# Altium schematic sheet Eco-Stationnement_Board_Connecteur.SchDoc(Eco-Stationnement_Board_Connecteur)
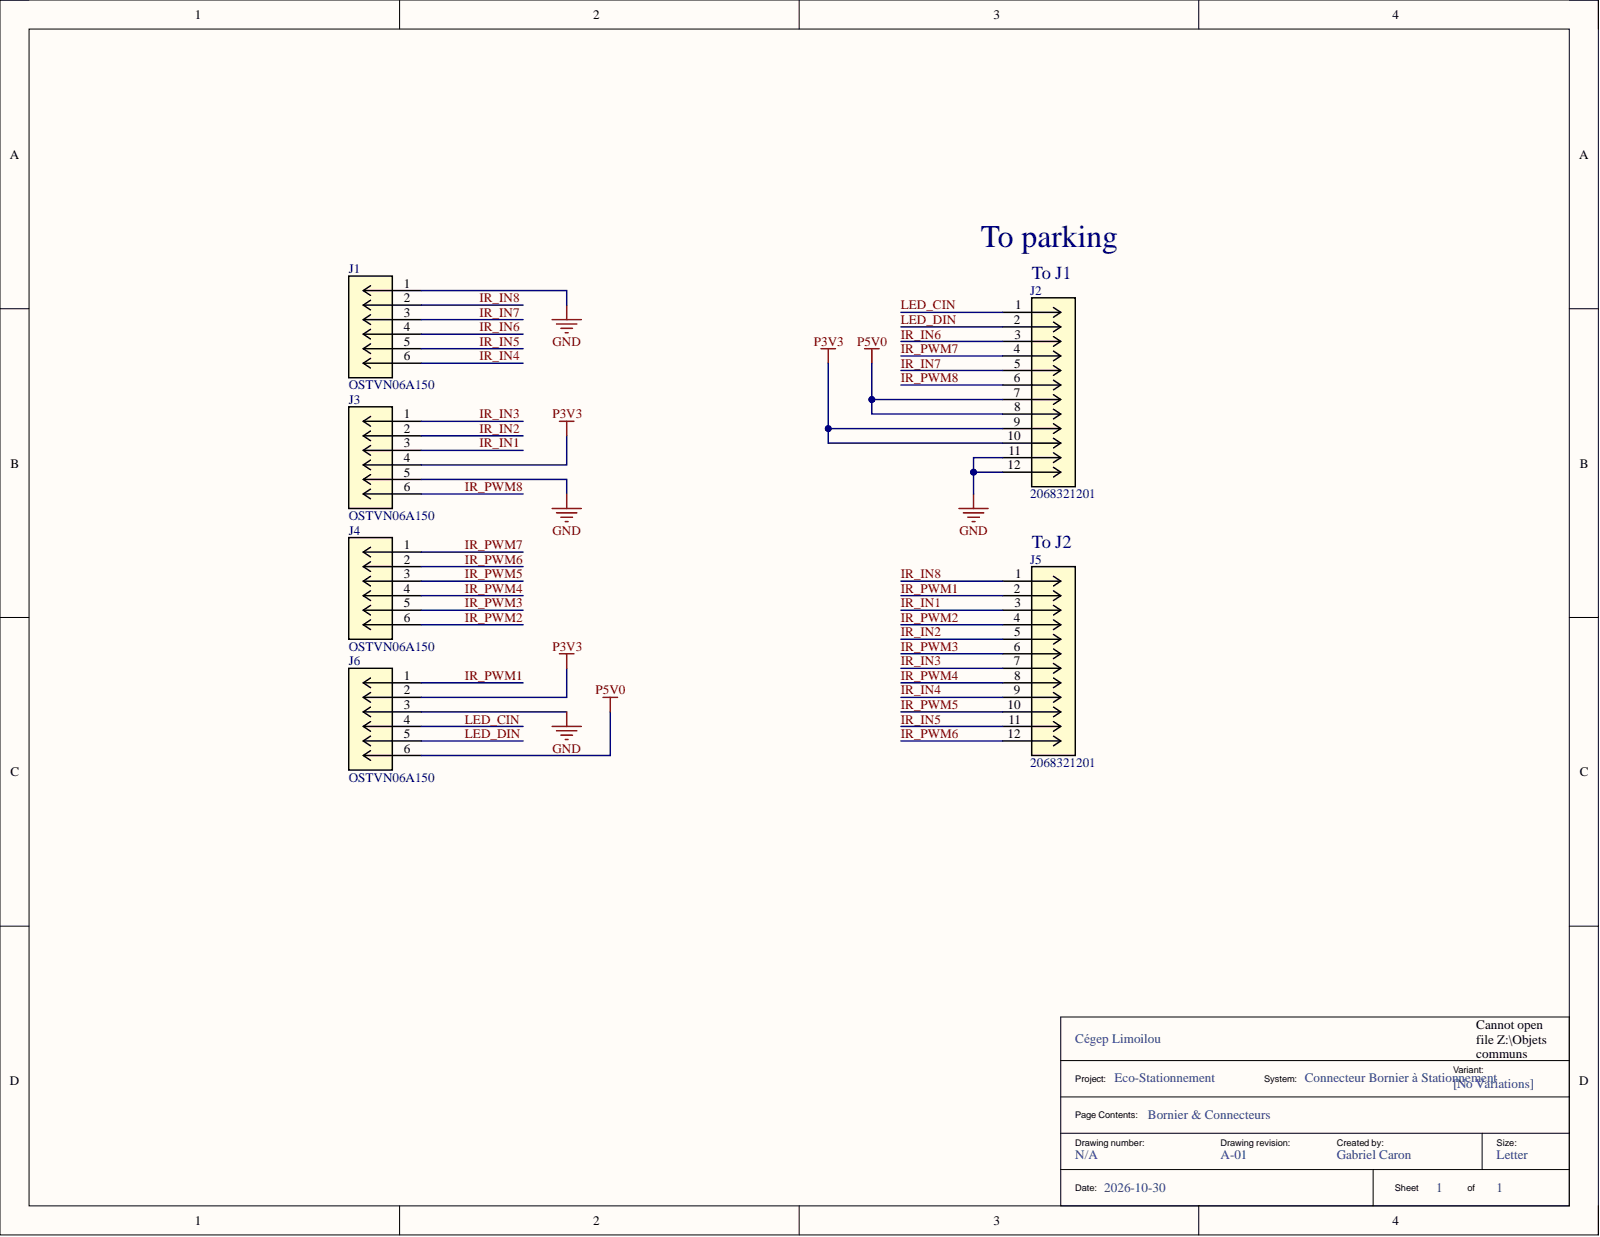
[diagram p0: Eco-Stationnement_Board_Connecteur.SchDoc]

## Parts
J1  OSTVN06A150  [CONN_OSTVN06A150_OST]
J2  2068321201  [CONN_2068320000-SD_12_MOL]
J3  OSTVN06A150  [CONN_OSTVN06A150_OST]
J4  OSTVN06A150  [CONN_OSTVN06A150_OST]
J5  2068321201  [CONN_2068320000-SD_12_MOL]
J6  OSTVN06A150  [CONN_OSTVN06A150_OST]

## Nets
GND: J1.1 J2.11 J2.12 J3.5 J6.3
P3V3: J2.9 J2.10 J3.4 J6.2
P5V0: J2.7 J2.8 J6.6
IR_IN1: J3.3 J5.3
IR_IN2: J3.2 J5.5
IR_IN3: J3.1 J5.7
IR_IN4: J1.6 J5.9
IR_IN5: J1.5 J5.11
IR_IN6: J1.4 J2.3
IR_IN7: J1.3 J2.5
IR_IN8: J1.2 J5.1
IR_PWM1: J5.2 J6.1
IR_PWM2: J4.6 J5.4
IR_PWM3: J4.5 J5.6
IR_PWM4: J4.4 J5.8
IR_PWM5: J4.3 J5.10
IR_PWM6: J4.2 J5.12
IR_PWM7: J2.4 J4.1
IR_PWM8: J2.6 J3.6
LED_CIN: J2.1 J6.4
LED_DIN: J2.2 J6.5

## Library data of the parts
J1 J3 J4 J6 = OSTVN06A150: TERM BLK 6P SIDE ENT 2.54MM PCB; Manufacturer: On Shore Technology Inc.; Manufacturer_Name: On Shore Technology; Component Kind: Standard; Fitted: Fitted; Manufacturer_Part_Number: OSTVN06A150; PN_Manuf: OSTVN06A150; RefDes: RefDes; Type: Type; Copyright: Copyright (C) 2025 Ultra Librarian. All rights reserved.; Library Name: Eco-Stationnement_Board_Connecteur.SchLib
J2 J5 = 2068321201: Micro-Fit+ Vertical Header, Through Hole, 3.00mm Pitch, Dual Row, 12 Circuits, Tin (Sn) Plating, Glow-Wire Capable, Black, Tray; Manufacturer: Molex Connector Corporation; Component Kind: Standard; Datasheet: https://www.molex.com/en-us/products/part-detail-pdf/2068321201?display=pdf; Fitted: Fitted; Manufacturer_Name: Molex Connector Corporation; Manufacturer_Part_Number: 2068321201; PN_Manuf: 2068321201; RefDes: RefDes; Type: Type; Copyright: Copyright (C) 2026 Ultra Librarian. All rights reserved.; Library Name: Eco-Stationnement_Board_Connecteur.SchLib
